# Altium schematic sheet main.SchDoc(main)
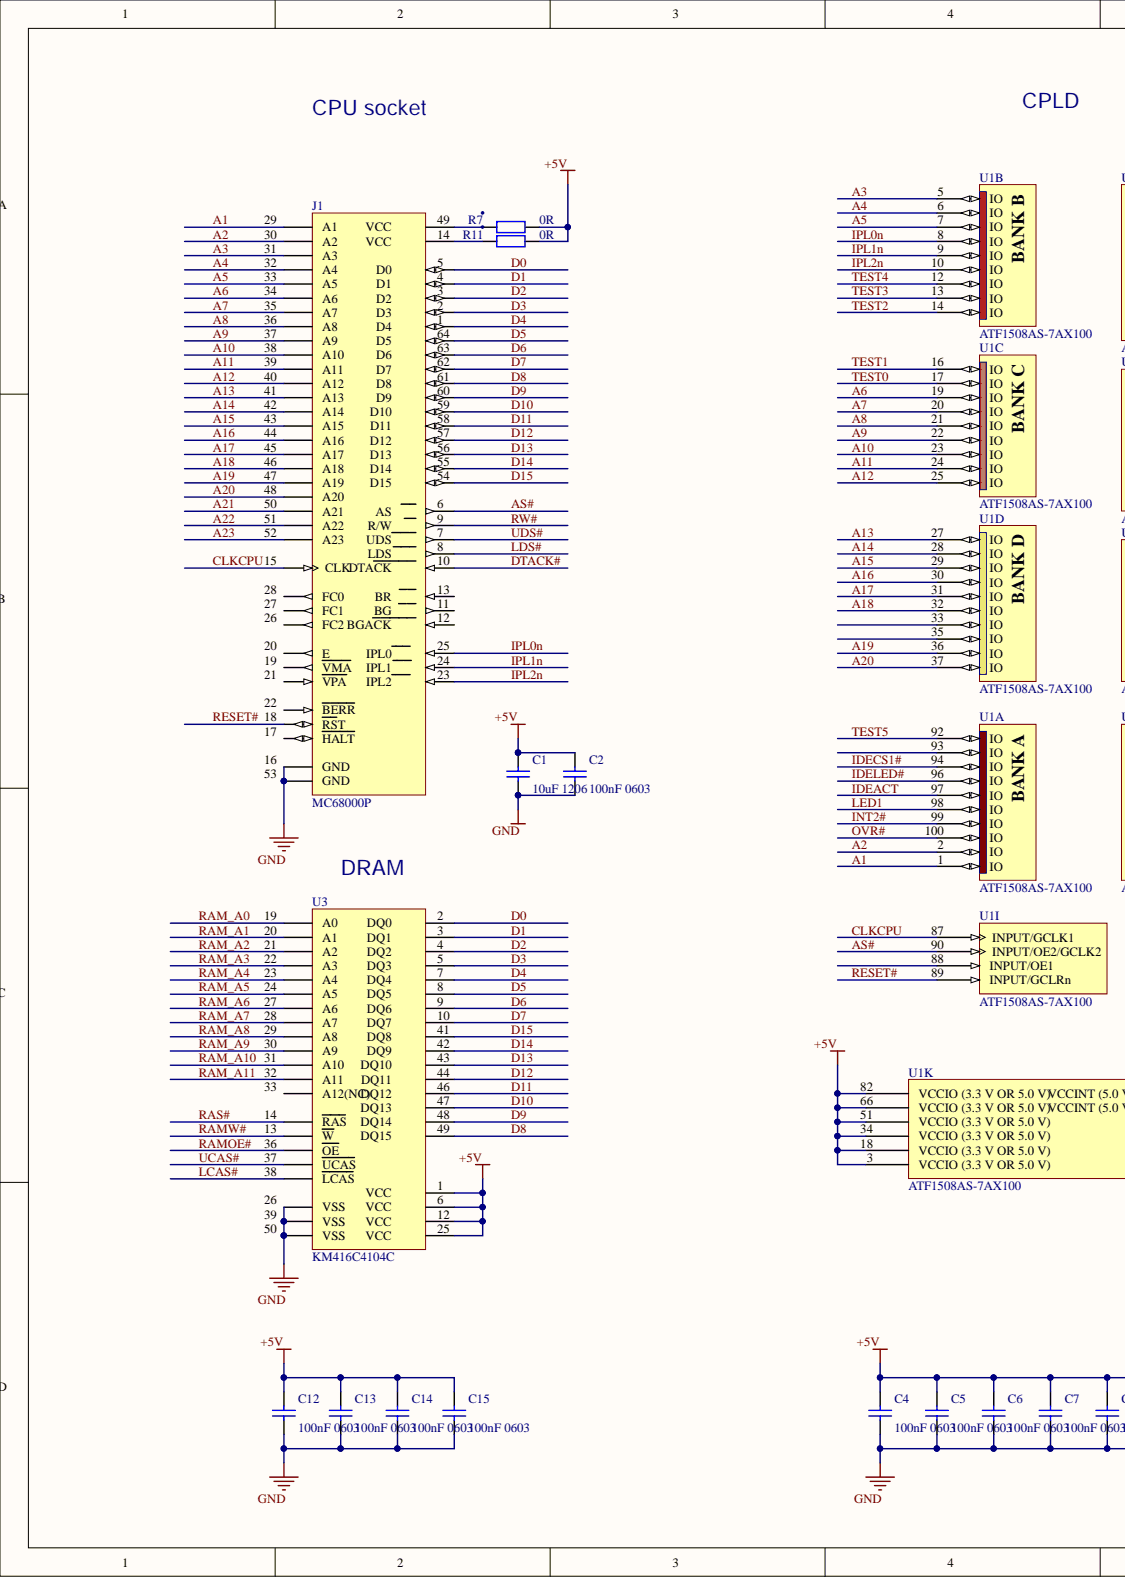
[diagram p0: main.SchDoc, left]
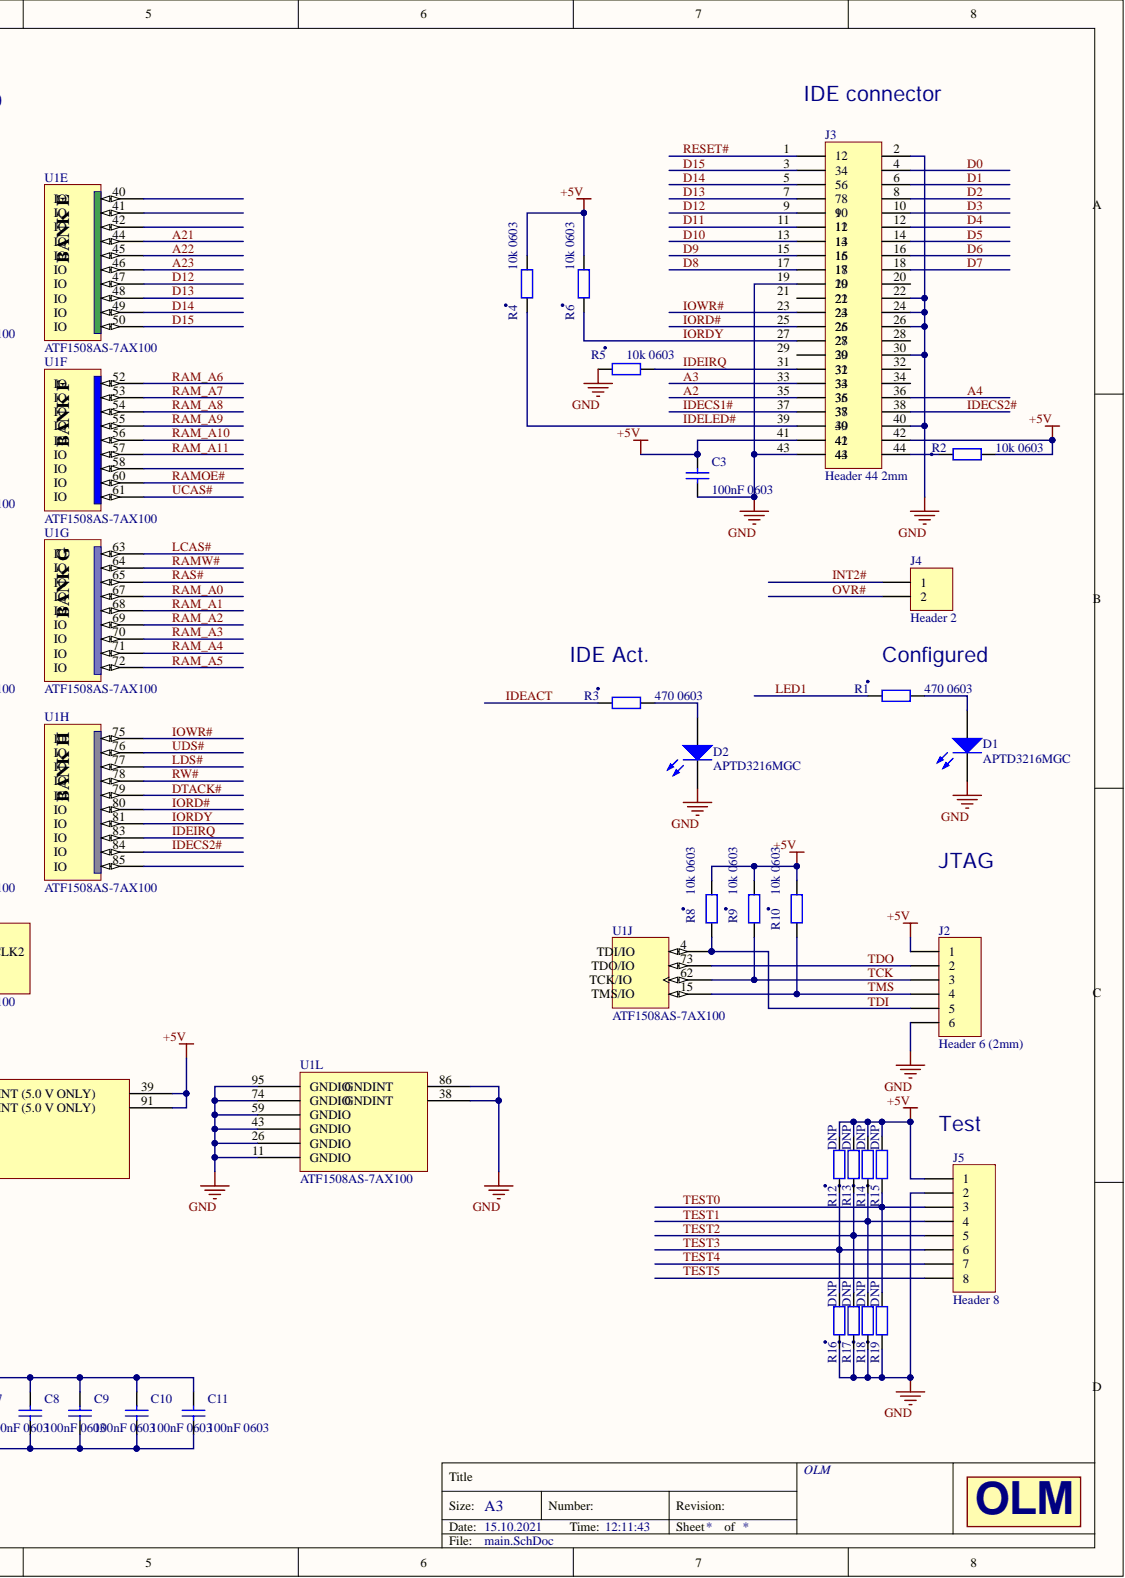
[diagram p1: main.SchDoc, right]

## Parts
C1  value 10uF 1206  [SM/C_1206]  (hidden comment: Capacitor)
C2  value 100nF 0603  [SM/C_0603]  (hidden comment: Capacitor)
C3  value 100nF 0603  [SM/C_0603]  (hidden comment: Cap)
C4  Cap  [SM/C_0603]  (value not drawn)
C5  value 100nF 0603  [SM/C_0603]  (hidden comment: Cap)
C6  value 100nF 0603  [SM/C_0603]  (hidden comment: Cap)
C7  value 100nF 0603  [SM/C_0603]  (hidden comment: Cap)
C8  value 100nF 0603  [SM/C_0603]  (hidden comment: Cap)
C9  value 100nF 0603  [SM/C_0603]  (hidden comment: Cap)
C10  value 100nF 0603  [SM/C_0603]  (hidden comment: Cap)
C11  value 100nF 0603  [SM/C_0603]  (hidden comment: Cap)
C12  Cap  [SM/C_0603]  (value not drawn)
C13  value 100nF 0603  [SM/C_0603]  (hidden comment: Cap)
C14  value 100nF 0603  [SM/C_0603]  (hidden comment: Cap)
C15  value 100nF 0603  [SM/C_0603]  (hidden comment: Cap)
D1  value APTD3216MGC  [SM/LED_0805_21_BLUE]  (hidden comment: Светодиод)
D2  value APTD3216MGC  [SM/LED_0805_21_BLUE]  (hidden comment: Светодиод)
J1  MC68000P  [DIP.100/64/W.900-SKT]
J2  Header 6 (2mm)  [PLS-6]
J3  Header 44 2mm  [PLD2-44]
J4  Header 2  [HDR1X2]
J5  Header 8  [PLS2-8]
R1  value 470 0603  [SM/R_0603]  (hidden comment: Резистор)
R2  value 10k 0603  [SM/R_0603]  (hidden comment: Резистор)
R3  value 470 0603  [SM/R_0603]  (hidden comment: Резистор)
R4  value 10k 0603  [SM/R_0603]  (hidden comment: Resistor)
R5  value 10k 0603  [SM/R_0603]  (hidden comment: Resistor)
R6  value 10k 0603  [SM/R_0603]  (hidden comment: Resistor)
R7  value 0R  [SM/R_0603]  (hidden comment: Резистор)
R8  value 10k 0603  [SM/R_0603]  (hidden comment: Resistor)
R9  value 10k 0603  [SM/R_0603]  (hidden comment: Resistor)
R10  value 10k 0603  [SM/R_0603]  (hidden comment: Resistor)
R11  value 0R  [SM/R_0603]  (hidden comment: Резистор)
R12  value DNP  [SM/R_0603]  (hidden comment: Resistor)  (no BOM)
R13  value DNP  [SM/R_0603]  (hidden comment: Resistor)  (no BOM)
R14  value DNP  [SM/R_0603]  (hidden comment: Resistor)  (no BOM)
R15  value DNP  [SM/R_0603]  (hidden comment: Resistor)  (no BOM)
R16  value DNP  [SM/R_0603]  (hidden comment: Resistor)  (no BOM)
R17  value DNP  [SM/R_0603]  (hidden comment: Resistor)  (no BOM)
R18  value DNP  [SM/R_0603]  (hidden comment: Resistor)  (no BOM)
R19  value DNP  [SM/R_0603]  (hidden comment: Resistor)  (no BOM)
U1  ATF1508AS-7AX100  [TQFP100_16mm]  (parts A,B,C,D,E,F,G,H,I,J,K,L)
U3  value KM416C4104C  [TSOP-II-50]  (hidden comment: 4Mx16 DRAM TSOP50)

## Nets
+5V: C1.2 C2.2 C3.2 C4.2 C5.2 C6.2 C7.2 C8.2 C9.2 C10.2 C11.2 C12.2 C13.2 C14.2 C15.2 J2.1 J3.41 J3.42 J5.1 R2.2 R4.2 R6.2 R7.1 R8.2 R9.2 R10.2 R11.1 R12.2 R13.2 R14.2 R15.2 U1.3(VCCIO_(3.3_V_OR_5.0_V)) U1.18(VCCIO_(3.3_V_OR_5.0_V)) U1.34(VCCIO_(3.3_V_OR_5.0_V)) U1.39 U1.51(VCCIO_(3.3_V_OR_5.0_V)) U1.66(VCCIO_(3.3_V_OR_5.0_V)) U1.82(VCCIO_(3.3_V_OR_5.0_V)) U1.91 U3.1 U3.6 U3.12 U3.25
GND: C1.1 C2.1 C3.1 C4.1 C5.1 C6.1 C7.1 C8.1 C9.1 C10.1 C11.1 C12.1 C13.1 C14.1 C15.1 D1.2 D2.2 J1.16(GND) J1.53(GND) J2.6 J3.2 J3.19 J3.22 J3.24 J3.26 J3.30 J3.40 J3.43 J5.2 R5.1 R16.1 R17.1 R18.1 R19.1 U1.11(GNDIO) U1.26(GNDIO) U1.38 U1.43(GNDIO) U1.59(GNDIO) U1.74(GNDIO) U1.86 U1.95(GNDIO) U3.26(VSS) U3.39(VSS) U3.50(VSS)
A1: J1.29(A1) U1.1(IO)
A2: J1.30(A2) J3.35 U1.2(IO)
A3: J1.31(A3) J3.33 U1.5(IO)
A4: J1.32(A4) J3.36 U1.6(IO)
A5: J1.33(A5) U1.7(IO)
A6: J1.34(A6) U1.19(IO)
A7: J1.35(A7) U1.20(IO)
A8: J1.36(A8) U1.21(IO)
A9: J1.37(A9) U1.22(IO)
A10: J1.38(A10) U1.23(IO)
A11: J1.39(A11) U1.24(IO)
A12: J1.40(A12) U1.25(IO)
A13: J1.41(A13) U1.27(IO)
A14: J1.42(A14) U1.28(IO)
A15: J1.43(A15) U1.29(IO)
A16: J1.44(A16) U1.30(IO)
A17: J1.45(A17) U1.31(IO)
A18: J1.46(A18) U1.32(IO)
A19: J1.47(A19) U1.36(IO)
A20: J1.48(A20) U1.37(IO)
A21: J1.50(A21) U1.44
A22: J1.51(A22) U1.45
A23: J1.52(A23) U1.46
AS#: J1.6 U1.90(INPUT/OE2/GCLK2)
CLKCPU: J1.15(CLK) U1.87(INPUT/GCLK1)
D0: J1.5 J3.4 U3.2
D1: J1.4 J3.6 U3.3
D2: J1.3 J3.8 U3.4
D3: J1.2 J3.10 U3.5
D4: J1.1 J3.12 U3.7
D5: J1.64 J3.14 U3.8
D6: J1.63 J3.16 U3.9
D7: J1.62 J3.18 U3.10
D8: J1.61 J3.17 U3.49
D9: J1.60 J3.15 U3.48
D10: J1.59 J3.13 U3.47
D11: J1.58 J3.11 U3.46
D12: J1.57 J3.9 U1.47 U3.44
D13: J1.56 J3.7(7_8) U1.48 U3.43
D14: J1.55 J3.5(5_6) U1.49 U3.42
D15: J1.54 J3.3(3_4) U1.50 U3.41
DTACK#: J1.10 U1.79
IDEACT: R3.2 U1.97(IO)
IDECS1#: J3.37 U1.94(IO)
IDECS2#: J3.38 U1.84
IDEIRQ: J3.31 R5.2 U1.83
IDELED#: J3.39 R4.1 U1.96(IO)
INT2#: J4.1 U1.99(IO)
IORD#: J3.25 U1.80
IORDY: J3.27 R6.1 U1.81
IOWR#: J3.23 U1.75(H)
IPL0N: J1.25 U1.8(IO)
IPL1N: J1.24 U1.9(IO)
IPL2N: J1.23 U1.10(IO)
LCAS#: U1.63(G) U3.38(LCAS)
LDS#: J1.8 U1.77
LED1: R1.2 U1.98(IO)
OVR#: J4.2 U1.100(IO)
RAM_A0: U1.67 U3.19(A0)
RAM_A1: U1.68 U3.20(A1)
RAM_A2: U1.69 U3.21(A2)
RAM_A3: U1.70 U3.22(A3)
RAM_A4: U1.71 U3.23(A4)
RAM_A5: U1.72 U3.24(A5)
RAM_A6: U1.52 U3.27(A6)
RAM_A7: U1.53 U3.28(A7)
RAM_A8: U1.54 U3.29(A8)
RAM_A9: U1.55 U3.30(A9)
RAM_A10: U1.56 U3.31(A10)
RAM_A11: U1.57 U3.32(A11)
RAMOE#: U1.60 U3.36(OE)
RAMW#: U1.64 U3.13(W)
RAS#: U1.65 U3.14(RAS)
RESET#: J1.18(RST) J3.1(1_2) U1.89(INPUT/GCLRn)
RW#: J1.9 U1.78
TCK: J2.3 R9.1 U1.62
TDI: J2.5 R8.1 U1.4
TDO: J2.2 U1.73
TEST0: J5.3 R15.1 R19.2 U1.17(IO)
TEST1: J5.4 R14.1 R18.2 U1.16(IO)
TEST2: J5.5 R13.1 R17.2 U1.14(IO)
TEST3: J5.6 R12.1 R16.2 U1.13(IO)
TEST4: J5.7 U1.12(IO)
TEST5: J5.8 U1.92(IO)
TMS: J2.4 R10.1 U1.15
UCAS#: U1.61 U3.37(UCAS)
UDS#: J1.7 U1.76
NetD1_1: D1.1 R1.1
NetD2_1: D2.1 R3.1
NetJ1_14: J1.14 R11.2
NetJ1_49: J1.49 R7.2
NetJ3_44: J3.44 R2.1

Not connected: J1 11 12 13 17(HALT) 19(VMA) 20(E) 21(VPA) 22(BERR) 26 27(FC1) 28(FC0); J3 20 21 28 29 32 34; U1 33(IO) 35(IO) 40 41 42 58 85 88(INPUT/OE1) 93(IO); U3 33(A12(NC))

## Library data of the parts
C1 = Cap ceramic: Ceramic capacitor SMD; Manufacturer: Kemet; Part: C1206C106K4RACTU; Value: 10uF 1206; Component Kind: Standard; Library Name: New.SCHLIB
C2 = Cap ceramic: Ceramic capacitor SMD; Manufacturer: Samsung; Part: CL10B104KB8NNNL; Component Kind: Standard; Library Name: New.SCHLIB
C3 C5-C11 C13-C15 = Cap ceramic: CAP-100Nf; Component Kind: Standard; Library Name: New.SCHLIB
C4 C12 = Cap ceramic: CAP-100Nf; Value: 100nF 0603; Component Kind: Standard; Library Name: New.SCHLIB
D1 D2 = LED0: Typical INFRARED GaAs LED; Примечание: Kingbright; Component Kind: Standard; LatestRevisionDate: 08-Jul-2005; LatestRevisionNote: Mech Layer 1 removed.; Library Name: Miscellaneous Devices.IntLib; PackageDescription: LED; 2 Leads; PackageReference: LED-0; Published: 8-Jun-2000; Publisher: Altium Limited
J1 = MC68000P: 16-Bit/32-Bit Microprocessor; Value: Socket 1-pin x 64; Component Kind: Standard; DatasheetVersion: 1990; LatestRevisionDate: 06-Jun-2005; LatestRevisionNote: Stylized 3D Model Added.; Library Name: Motorola Microprocessor 16-Bit.IntLib; PackageDescription: DIP; 64 Leads; Row Spacing 22.86 mm; Pitch 2.54 mm; PackageReference: 754-01; PCB3D: 746-01; Published: 17-Jul-2002; Publisher: Altium Limited
J2 = Header 6: Header, 6-Pin; Component Kind: Standard; LatestRevisionDate: 17-Jul-2002; LatestRevisionNote: Re-released for DXP Platform.; Library Name: Miscellaneous Connectors.IntLib; Signal Integrity: Connector; Publisher: Altium Limited
J3 = Header 22X2: Header, 22-Pin, Dual row; Component Kind: Standard; LatestRevisionDate: 17-Jul-2002; LatestRevisionNote: Re-released for DXP Platform.; Library Name: Miscellaneous Connectors.IntLib; Signal Integrity: Connector; Publisher: Altium Limited
J4 = Header 2: Header, 2-Pin; Value: PLS-2; Component Kind: Standard; LatestRevisionDate: 17-Jul-2002; LatestRevisionNote: Re-released for DXP Platform.; Library Name: Miscellaneous Connectors.IntLib; Signal Integrity: Connector; Publisher: Altium Limited
J5 = Header 8: Header, 8-Pin; Component Kind: Standard; LatestRevisionDate: 17-Jul-2002; LatestRevisionNote: Re-released for DXP Platform.; Library Name: Miscellaneous Connectors.IntLib; Signal Integrity: Connector; Publisher: Altium Limited
R1 R3 = Res2: Resistor; Value: 470 0603; Component Kind: Standard; LatestRevisionDate: 17-Jul-2002; LatestRevisionNote: Re-released for DXP Platform.; Library Name: Miscellaneous Devices.IntLib; PackageReference: AXIAL-0.4; Published: 8-Jun-2000; Signal Integrity: Res; Simulation: RESISTOR; Publisher: Altium Limited
R2 R7 R11 = Res2: Resistor; Component Kind: Standard; LatestRevisionDate: 17-Jul-2002; LatestRevisionNote: Re-released for DXP Platform.; Library Name: Miscellaneous Devices.IntLib; PackageReference: AXIAL-0.4; Published: 8-Jun-2000; Signal Integrity: Res; Simulation: RESISTOR; Publisher: Altium Limited
R4-R6 R8-R10 R12-R19 = Res2: Part: 0R 5% 0603; Description: Resistor; LatestRevisionDate: 17-Jul-2002; LatestRevisionNote: Re-released for DXP Platform.; Library Name: Miscellaneous Devices.IntLib; PackageReference: AXIAL-0.4; Published: 8-Jun-2000; Signal Integrity: Res; Simulation: RESISTOR; Publisher: Altium Limited
  R4-R6 R8-R10: Component Kind: Standard
U1 = EPM7128STC100-7: MAX 7000S 5V In-System Programmable CPLD, 128 Macrocells, 100-Pin TQFP, Speed Grade 7, Commercial Grade; Component Kind: Standard; ComponentLink2Description: Datasheet; ComponentLink3Description: Packaging Specification; ComponentLink3URL: http://www.altera.com/literature/ds/dspkg.pdf; Library Name: Altera MAX 7000S.IntLib; PackageReference: TQFP100; PCB3D: EPM7128S-TQFP100; Signal Integrity: 7k; Supplier 1: Digi-Key; Supplier Part Number 1: 544-1785-ND; Value: ATF1508AS-7AX100
U3 = 4Mx16 DRAM: 4Mx16 EDO DRAM TSOP-II-50; Component Kind: Standard; Library Name: Memory.SchLib
